# Altium schematic sheet Sheet1.SchDoc(Sheet1)
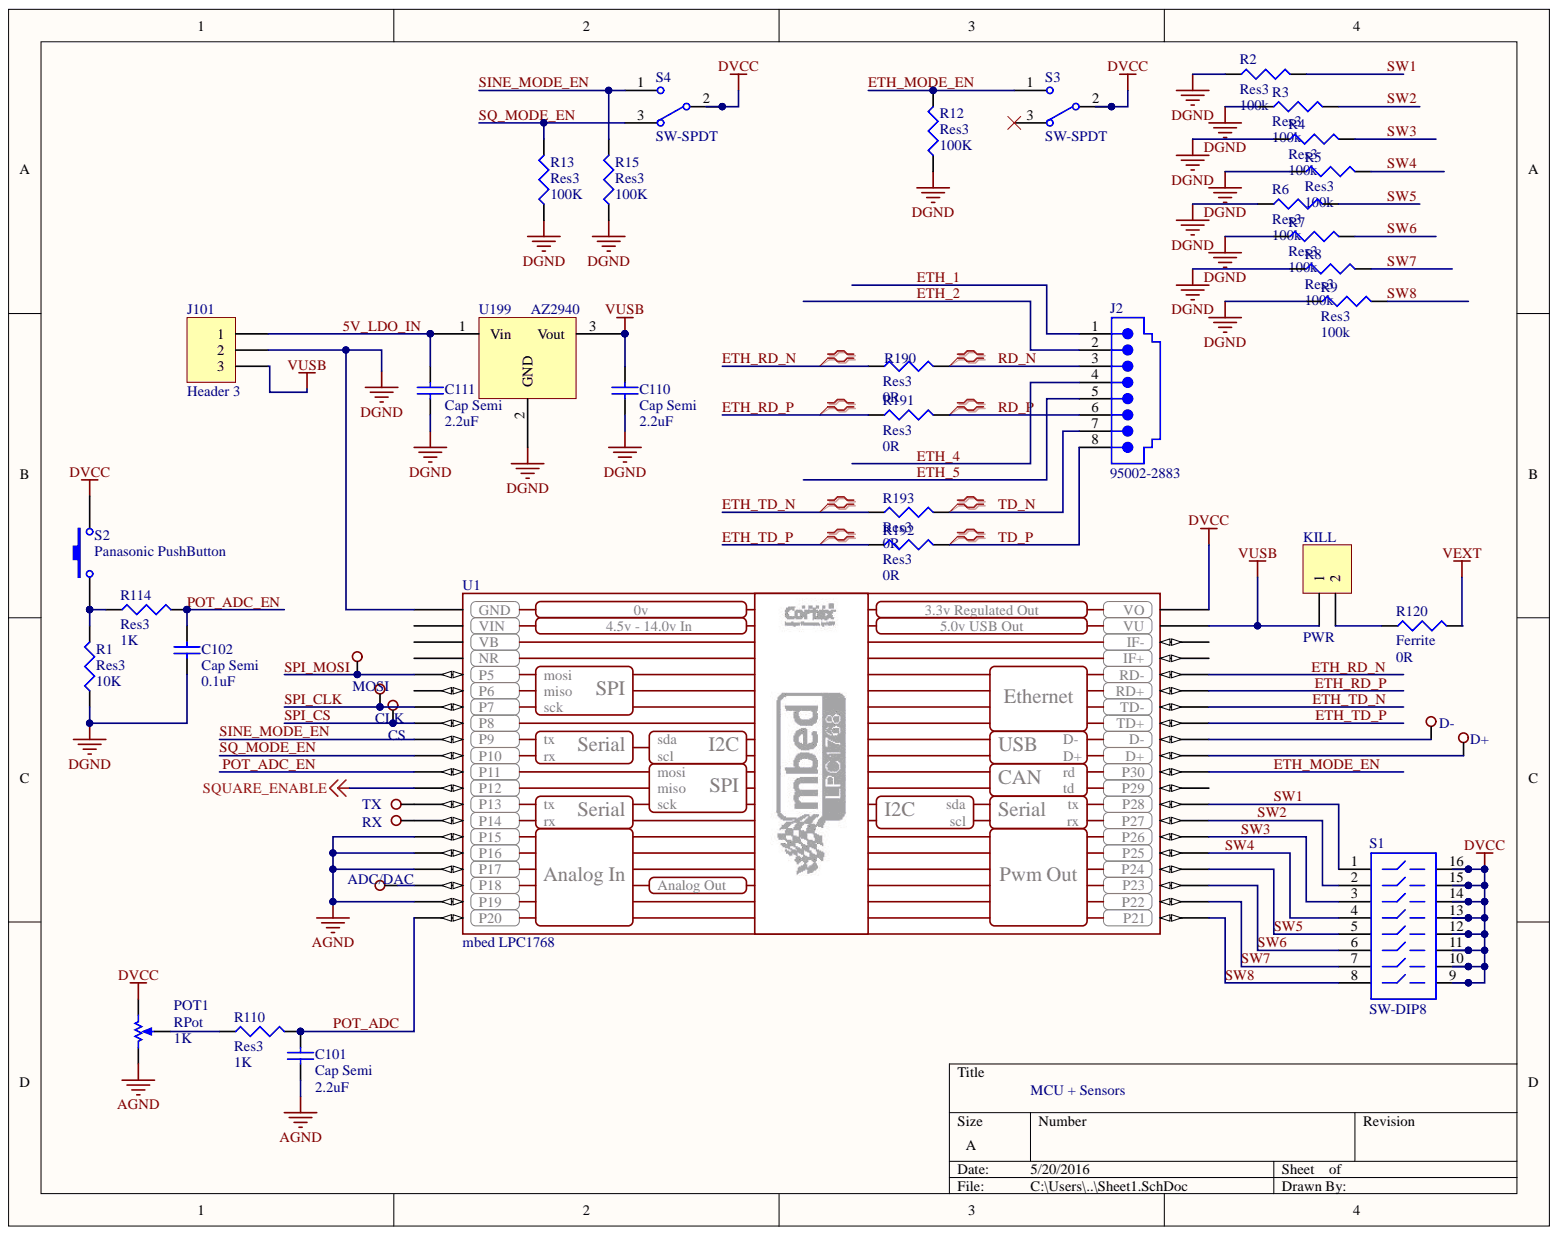
[diagram p0: Sheet1.SchDoc]

## Parts
ADC/DAC  TEST_POINT  [TEST_POINT]  (value not drawn)
C101  Cap Semi  value 2.2uF  [1608[0603]]
C102  Cap Semi  value 0.1uF  [1608[0603]]
C110  Cap Semi  value 2.2uF  [1608[0603]]
C111  Cap Semi  value 2.2uF  [1608[0603]]
CLK  TEST_POINT  [TEST_POINT]  (value not drawn)
CS  TEST_POINT  [TEST_POINT]  (value not drawn)
D+  TEST_POINT  [TEST_POINT]  (value not drawn)
D-  TEST_POINT  [TEST_POINT]  (value not drawn)
J2  95002-2883  [Eth_jack]
J101  Header 3  [HDR1X3]
KILL  PWR  [HDR1X2]
MOSI  TEST_POINT  [TEST_POINT]  (value not drawn)
POT1  RPot  value 1K  [296UD502B1]
R1  Res3  value 10K  [J1-0603]
R2  Res3  [J1-0603]
R3  Res3  value 100k  [J1-0603]
R4  Res3  value 100k  [J1-0603]
R5  Res3  value 100k  [J1-0603]
R6  Res3  value 100k  [J1-0603]
R7  Res3  value 100k  [J1-0603]
R8  Res3  value 100k  [J1-0603]
R9  Res3  value 100k  [J1-0603]
R12  Res3  value 100K  [J1-0603]
R13  Res3  value 100K  [J1-0603]
R15  Res3  value 100K  [J1-0603]
R110  Res3  value 1K  [J1-0603]
R114  Res3  value 1K  [J1-0603]
R120  Ferrite  value 0R  [6-0805_M]
R190  Res3  [J1-0603]
R191  Res3  value 0R  [J1-0603]
R192  Res3  value 0R  [J1-0603]
R193  Res3  value 0R  [J1-0603]
RX  TEST_POINT  [TEST_POINT]  (value not drawn)
S1  SW-DIP8  [DIP-16-KEY]
S2  Panasonic PushButton  [Panasonic PushButton]
S3  SW-SPDT  [CKN9565-ND]
S4  SW-SPDT  [M2013EA2W40]
TX  TEST_POINT  [TEST_POINT]  (value not drawn)
U1  mbed LPC1768  [LPC1768]
U199  AZ2940  [TO252-3M]

## Nets
AGND: C101.1 POT1.2 U1.15(P15) U1.16(P16) U1.17(P17) U1.19(P19)
DGND: C102.1 C110.2 C111.2 J101.2 R1.1 R2.1 R3.1 R4.1 R5.1 R6.1 R7.1 R8.1 R9.1 R12.1 R13.1 R15.1 U1.1(GND) U199.2
DVCC: POT1.1 S1.9 S1.10 S1.11 S1.12 S1.13 S1.14 S1.15 S1.16 S2.2 S3.2 S4.2 U1.40(VO)
SQUARE_ENABLE: U1.12(P12)
VEXT: R120.2
VUSB: C110.1 J101.3 KILL.1 U1.39(VU) U199.3
5V_LDO_IN: C111.1 J101.1 U199.1
ETH_1: J2.1
ETH_2: J2.2
ETH_4: J2.4
ETH_5: J2.5
ETH_MODE_EN: R12.2 S3.1 U1.30(P30)
ETH_RD_N: R190.1 U1.36(RD-)
ETH_RD_P: R191.1 U1.35(RD+)
ETH_TD_N: R193.1 U1.34(TD-)
ETH_TD_P: R192.1 U1.33(TD+)
POT_ADC: C101.2 R110.2 U1.20(P20)
POT_ADC_EN: C102.2 R114.2 U1.11(P11)
RD_N: J2.3 R190.2
RD_P: J2.6 R191.2
SINE_MODE_EN: R15.2 S4.1 U1.9(P9)
SPI_CLK: CLK.0 U1.7(P7)
SPI_CS: CS.0 U1.8(P8)
SPI_MOSI: MOSI.0 U1.5(P5)
SQ_MODE_EN: R13.2 S4.3 U1.10(P10)
SW1: R2.2 S1.1 U1.28(P28)
SW2: R3.2 S1.2 U1.27(P27)
SW3: R4.2 S1.3 U1.26(P26)
SW4: R5.2 S1.4 U1.25(P25)
SW5: R6.2 S1.5 U1.24(P24)
SW6: R7.2 S1.6 U1.23(P23)
SW7: R8.2 S1.7 U1.22(P22)
SW8: R9.2 S1.8 U1.21(P21)
TD_N: J2.7 R193.2
TD_P: J2.8 R192.2
NetADC/DAC_0: ADC/DAC.0 U1.18(P18)
NetD+_0: D+.0 U1.31(D+)
NetD-_0: D-.0 U1.32(D-)
NetKILL_2: KILL.2 R120.1
NetPOT1_3: POT1.3 R110.1
NetR1_2: R1.2 R114.1 S2.1
NetRX_0: RX.0 U1.14(P14)
NetTX_0: TX.0 U1.13(P13)

Not connected: S3 3; U1 2(VIN) 3(VB) 4(NR) 6(P6) 29(P29) 37(IF+) 38(IF-)

## Library data of the parts
C101 C102 C110 C111 = Cap Semi: Capacitor (Semiconductor SIM Model); Component Kind: Standard; LatestRevisionDate: 29-May-2009; LatestRevisionNote: IPC-7351 Footprint Added.; Library Name: Miscellaneous Devices.IntLib; PackageDescription: Chip Capacitor; PackageReference: C1206; Published: 8-Jun-2000; Signal Integrity: Cap Semi; Simulation: CAP; Publisher: Altium Limited
J2 = 95002-2883: Bottom Entry, Low Profile, PCB Jack; DrillSize: Nominal, Rounded to nearest 0.05mm; PCB_Layout: Complies with Manufacturer's Recommendation.; PCB_Mounting: Thru-Hole; PerformanceCategory: 3; Ports: 1; Positions/Loaded: Port1 - 8/8; Component Kind: Standard; ComponentLink1Description: Manufacturer Link; ComponentLink1URL: http://www.molex.com/; ComponentLink2Description: Datasheet; ComponentLink2URL: http://www.molex.com/cmc_upload/common_files/1222003_mx95002.pdf; ComponentLink3Description: Iges Model; ComponentLink3URL: http://www.molex.com/pdm_docs/igs/95002-2443_igs.zip; DatasheetDocument: 9-Nov-2001; Library Name: Molex Modular Jack Bottom.IntLib; PackageDocument: 09-Nov-2001; PackageReference: 95002-2883; Published: 25-Jun-2002; Signal Integrity: Modular Jack; Publisher: Altium Limited
J101 = Header 3: Header, 3-Pin; Component Kind: Standard; LatestRevisionDate: 17-Jul-2002; LatestRevisionNote: Re-released for DXP Platform.; Library Name: Miscellaneous Connectors.IntLib; Signal Integrity: Connector; Publisher: Altium Limited
KILL = Header 2: Header, 2-Pin; Component Kind: Standard; LatestRevisionDate: 17-Jul-2002; LatestRevisionNote: Re-released for DXP Platform.; Library Name: Miscellaneous Connectors.IntLib; Signal Integrity: Connector; Publisher: Altium Limited
POT1 = RPot: Potentiometer; Set Position: 0.5; Sim Note: Enter the Resistance value and the set point into parameter fields.; Component Kind: Standard; LatestRevisionDate: 17-Jul-2002; LatestRevisionNote: Re-released for DXP Platform.; Library Name: Miscellaneous Devices.IntLib; PackageDescription: Variable Resistor, Thru-Hole; 3 Leads; PackageReference: VR5; Published: 8-Jun-2000; Signal Integrity: Guessed Model; Simulation: POT; Publisher: Altium Limited
R1 R3-R9 R12 R13 R15 R110 R120 R191 R192 = Res3: Resistor; Component Kind: Standard; LatestRevisionDate: 29-May-2009; LatestRevisionNote: IPC-7351 Footprint Added.; Library Name: Miscellaneous Devices.IntLib; PackageDescription: Chip Resistor; PackageReference: J1-0603; Published: 8-Jun-2000; Signal Integrity: Res; Simulation: RESISTOR; Publisher: Altium Limited
R2 = Res3: Resistor; VALUE: 100k; Component Kind: Standard; LatestRevisionDate: 29-May-2009; LatestRevisionNote: IPC-7351 Footprint Added.; Library Name: Miscellaneous Devices.IntLib; PackageDescription: Chip Resistor; PackageReference: J1-0603; Published: 8-Jun-2000; Signal Integrity: Res; Simulation: RESISTOR; Publisher: Altium Limited
R114 = Res3: Resistor; VALUE: 1K; Component Kind: Standard; LatestRevisionDate: 29-May-2009; LatestRevisionNote: IPC-7351 Footprint Added.; Library Name: Miscellaneous Devices.IntLib; PackageDescription: Chip Resistor; PackageReference: J1-0603; Published: 8-Jun-2000; Signal Integrity: Res; Simulation: RESISTOR; Publisher: Altium Limited
R190 R193 = Res3: Resistor; VALUE: 0R; Component Kind: Standard; LatestRevisionDate: 29-May-2009; LatestRevisionNote: IPC-7351 Footprint Added.; Library Name: Miscellaneous Devices.IntLib; PackageDescription: Chip Resistor; PackageReference: J1-0603; Published: 8-Jun-2000; Signal Integrity: Res; Simulation: RESISTOR; Publisher: Altium Limited
S1 = SW-DIP8: 4009 Series DIP Switch, Raised actuator; Component Kind: Standard; ComponentLink1Description: Manufacturer Link; ComponentLink1URL: http://www.oupiin.com/prodindx.html#Headers; LatestRevisionDate: 17-Dec-2003; LatestRevisionNote: PCB Footprint replaced.; Library Name: Miscellaneous Devices.IntLib; PackageDescription: DIP; 16 Leads; Row Spacing 7.62 mm; Pitch 2.54 mm; PackageReference: DIP-16-KEY; Published: 24-Mar-1999; Signal Integrity: Guessed Model; Simulation: dpsw8; Publisher: Altium Limited
S3 S4 = SW-SPDT: SPDT Subminiature Toggle Switch, Right Angle Mounting, Vertical Actuation; Component Kind: Standard; ComponentLink1Description: Manufacturer Link; ComponentLink1URL: http://www.apem.com; DatasheetVersion: 05-Dec-2000; LatestRevisionDate: 17-Dec-2003; LatestRevisionNote: Schematic revised, PCB Footprint replaced.; Library Name: Miscellaneous Devices.IntLib; PackageDescription: Switch; 4 Leads; PackageReference: TL36WW15050; Published: 24-Mar-1999; Signal Integrity: Guessed Model; Publisher: Altium Limited
U199 = AZ2940: 5V 1A LDO; Component Kind: Standard; Library Name: Altium.SchLib; Signal Integrity: Guessed Model
ADC/DAC CLK CS D+ D- MOSI RX TX = TEST_POINT: Component Kind: Standard; Library Name: Altium.SchLib; Signal Integrity: Guessed Model
S2 = Panasonic PushButton: Component Kind: Standard; Library Name: Altium.SchLib; Signal Integrity: Guessed Model
U1 = mbed LPC1768: Component Kind: Standard; Library Name: CustomLibrary.intlib; Signal Integrity: Guessed Model
